# Altium schematic sheet MCU.SchDoc(MCU)
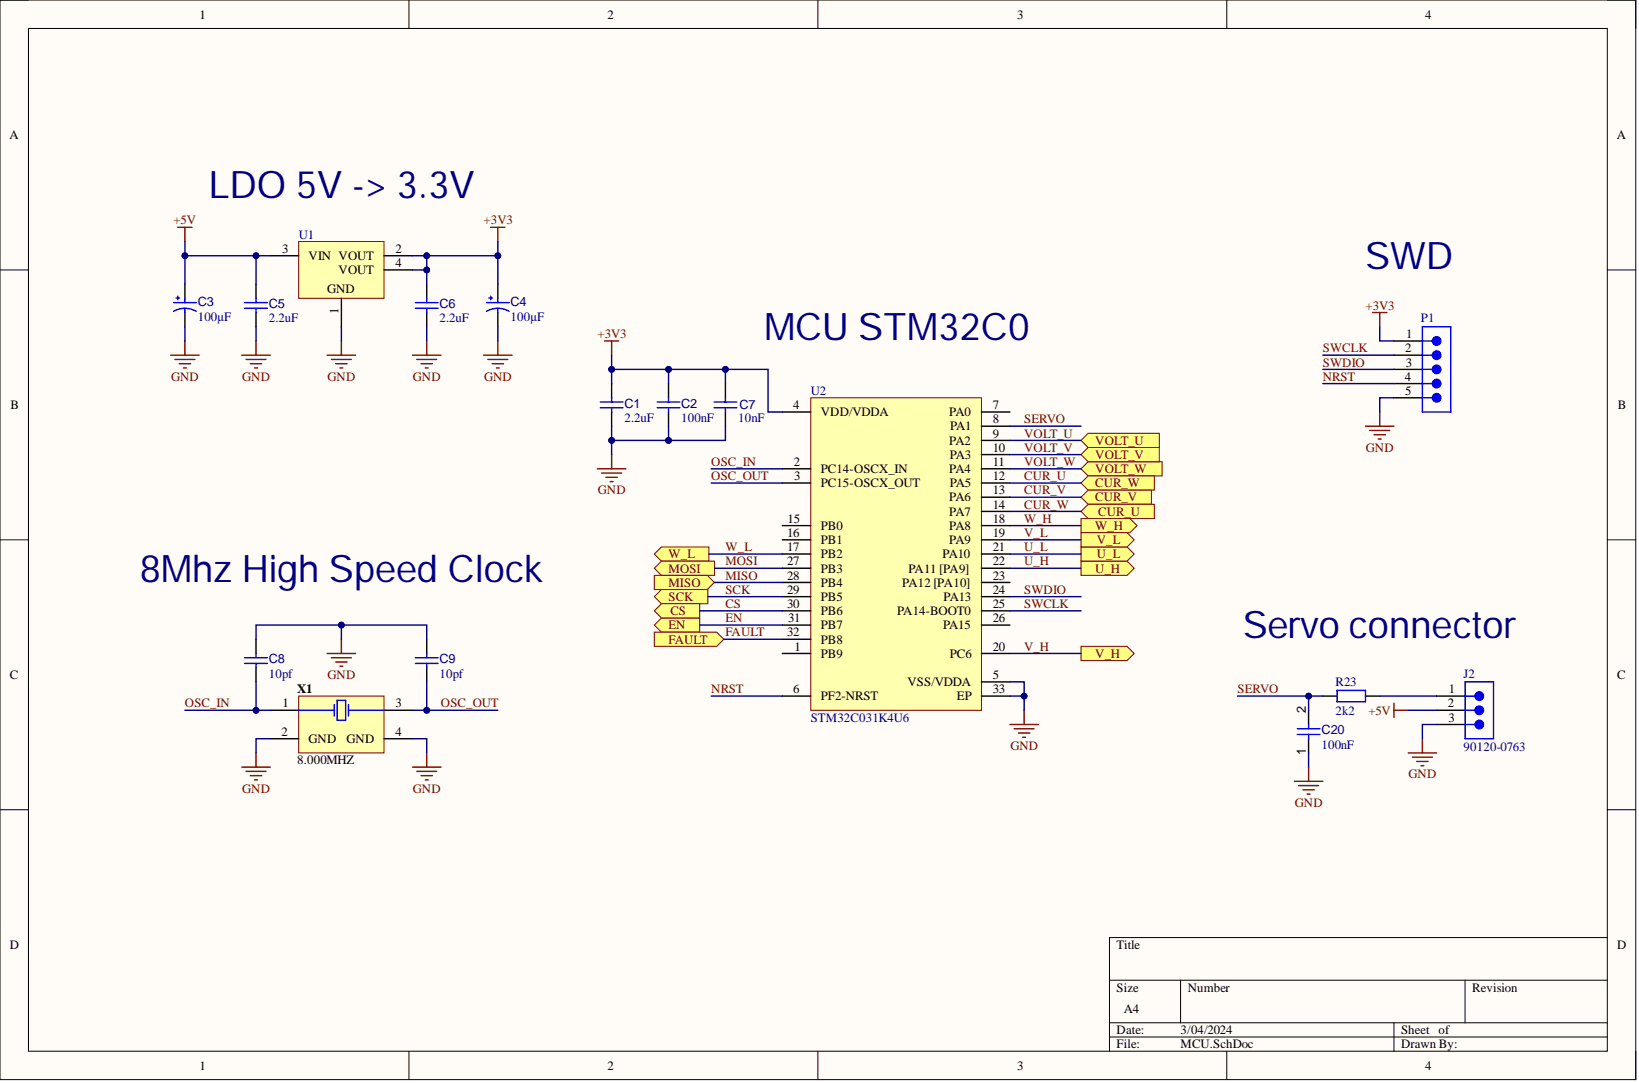
[diagram p0: MCU.SchDoc]

## Parts
C1  value 2.2uF  (hidden comment: Capacitor 100 nF +/-10% 50 V 0603)
C2  value 100nF  (hidden comment: Capacitor 100 nF +/-10% 50 V 0603)
C3  value 100µF  (hidden comment: Capacitor 150µF +/-20% 6.3V B2)
C4  value 100µF  (hidden comment: Capacitor 150µF +/-20% 6.3V B2)
C5  value 2.2uF  (hidden comment: Capacitor 100 nF +/-10% 50 V 0603)
C6  value 2.2uF  (hidden comment: Capacitor 100 nF +/-10% 50 V 0603)
C7  value 10nF  (hidden comment: Capacitor 100 nF +/-10% 50 V 0603)
C8  value 10pf  (hidden comment: Capacitor 100 nF +/-10% 50 V 0603)
C9  value 10pf  (hidden comment: Capacitor 100 nF +/-10% 50 V 0603)
C20  value 100nF  (hidden comment: Capacitor 100 nF +/-10% 50 V 0603)
J2  90120-0763
P1  61300511121  (value not drawn)
R23  value 2k2  (hidden comment: R0603)
U1  LD1117S33TR  (value not drawn)
U2  STM32C031K4U6
X1  8.000MHZ

## Ports
CS, CUR_U, CUR_V, CUR_W, EN, FAULT, MISO, MOSI, SCK, U_H, U_L, V_H, V_L, VOLT_U, VOLT_V, VOLT_W, W_H, W_L

## Nets
+3V3: C1.2 C2.2 C4.1 C6.2 C7.2 P1.1 U1.2(VOUT) U1.4(VOUT) U2.4(VDD/VDDA)
+5V: C3.1 C5.2 J2.2 U1.3(VIN)
GND: C1.1 C2.1 C3.2 C4.2 C5.1 C6.1 C7.1 C8.2 C9.2 C20.1 J2.3 P1.5 U1.1 U2.5(VSS/VDDA) U2.33(EP) X1.2(GND) X1.4(GND)
CS: U2.30(PB6) ; port CS
CUR_U: U2.12(PA5) ; port CUR_W
CUR_V: U2.13(PA6) ; port CUR_V
CUR_W: U2.14(PA7) ; port CUR_U
EN: U2.31(PB7) ; port EN
FAULT: U2.32(PB8) ; port FAULT
MISO: U2.28(PB4) ; port MISO
MOSI: U2.27(PB3) ; port MOSI
NRST: P1.4 U2.6(PF2-NRST)
OSC_IN: C8.1 U2.2(PC14-OSCX_IN) X1.1
OSC_OUT: C9.1 U2.3(PC15-OSCX_OUT) X1.3
SCK: U2.29(PB5) ; port SCK
SERVO: C20.2 R23.2 U2.8(PA1)
SWCLK: P1.2 U2.25(PA14-BOOT0)
SWDIO: P1.3 U2.24(PA13)
U_H: U2.22(PA11_[PA9]) ; port U_H
U_L: U2.21(PA10) ; port U_L
V_H: U2.20(PC6) ; port V_H
V_L: U2.19(PA9) ; port V_L
VOLT_U: U2.9(PA2) ; port VOLT_U
VOLT_V: U2.10(PA3) ; port VOLT_V
VOLT_W: U2.11(PA4) ; port VOLT_W
W_H: U2.18(PA8) ; port W_H
W_L: U2.17(PB2) ; port W_L
NetJ2_1: J2.1 R23.1

Not connected: U2 1(PB9) 7(PA0) 15(PB0) 16(PB1) 23(PA12_[PA10]) 26(PA15)

## Library data of the parts
C1 C2 C5-C9 C20 = CMP-001-00017-6: Chip Capacitor, 100 nF, +/- 10%, 50 V, 0603 (1608 Metric); Capacitor Type: Ceramic; Voltage Rating: 50V; Case/Package: 0603; Component Kind: Standard; Library Name: Test; Max Operating Temperature: 125°C; Min Operating Temperature: -55°C; Mounting Technology: SM; Pins: 2; RoHS Compliant: True; Tolerance: 10%
C3 C4 = CMP-001-00053-3: Molded Tantalum Polymer Capacitor, 150 uF, +/- 20%, 6.3 V, -55 to 105 degC, 2-Pin SMD, RoHS, Tape and Reel; Case/Package: 2917; Component Kind: Standard; Library Name: Test; Max Operating Temperature: 105°C; Min Operating Temperature: -55°C; Mounting Technology: SMT; Pins: 2; Tolerance: 20%; Voltage Rating: 6.3V
J2 = CMP-002-00071-1: Board Connector, 3 Contact(s), 1 Row(s), Male, Straight, 0.1 inch Pitch, Solder Terminal, Black Insulator, Receptacle; Component Kind: Standard; Connector Type: BOARD CONNECTOR; Library Name: Test; Max Operating Temperature: 125°C; Min Operating Temperature: -55°C; Mounting Technology: Board; RoHS Compliant: Yes
P1 = CMP-002-00074-2: Board Connector, 5 Contact(s), 1 Row(s), Male, Straight, 0.1 inch Pitch, Solder Terminal, Locking, Black Insulator, Plug; Component Kind: Standard; Connector Type: BOARD CONNECTOR; Library Name: Test; Max Operating Temperature: 125°C; Min Operating Temperature: -40°C; Mounting Technology: Board; RoHS Compliant: Yes
R23 = CMP-009-00179-11: Resistor SMD 0603; Component Kind: Standard; Library Name: Test
U1 = CMP-019-00019-3: Low Drop Positive Voltage Regulator, 800 mA, Fixed 3.3 V Output, 0 to 125 degC, 3-Pin TO-220, RoHS, Tape and Reel; Manufacturer: STMicroelectronics; Dropout Voltage: 1.1V; Max Input Voltage: 15V; Max Ouput Current: 1.3A; Min Input Voltage: 4.75V; Nominal Output Voltage: 3.3V; Output Current: 950mA; Outputs: 1; Power: 12W; Case/Package: TO-261-4; Component Kind: Standard; Datasheet URL: http://www.farnell.com/datasheets/1776449.pdf; Datasheet Version: Rev. 33, 11/2013; Library Name: Test; Manufacturer URL: http://www.st.com/; Max Operating Temperature: 125°C; Max Supply Voltage: 15V; Min Operating Temperature: 0°C; Min Supply Voltage: 4.75V; Mounting Technology: SM; Output Voltage: 3.3V; Package Description: 4-Pin Small Outline Transistor, Body 6.5 x 3.5 mm, Pitch 2.3 mm; Package Quantity: 2500; Package Reference: SOT-223; Packaging: TapeandReel; Pins: 3; RoHS Compliant: True
U2 = CMP-020-00024-2: ARM Cortex-M0+ 32-bit MCU, 32 KB Flash, 12 KB RAM, 2 x USART, timers, ADC, comm. I/Fs, 2-3.6 V; Clock Frequency: 48MHz; I/Os: 30; Nominal Supply Voltage: 3.3V; Case/Package: UFQFPN32; Component Kind: Standard; Library Name: Test; Mounting Technology: SMT
X1 = CMP-003-00021-2: Ceramic SMD Crystal, 8 MHz, +/- 20 ppm, 18 pF, -20 to 70 degC, 4-Pin SMD, RoHS, Tape and Reel; Manufacturer: Abracon; ESR: 200; Frequency: 8MHz; Frequency Tolerance: 20ppm; Load Capacitance: 18pF; Resistance: 200Ohm; Case/Package: CSMD; Component Kind: Standard; Datasheet URL: http://www.abracon.com/Resonators/abm3b.pdf; Datasheet Version: Aug-15; Library Name: Test; Manufacturer URL: http://www.abracon.com/; Max Operating Temperature: 70°C; Min Operating Temperature: -20°C; Mounting Technology: SM; Package Description: 4-Pin Surface Mount Device, Body 5 x 3.2 mm; Package Quantity: 1000; Package Reference: ABM3B; Packaging: TapeandReel; Pins: 4; RoHS Compliant: True; Tolerance: 20ppm
